# Altium schematic sheet PowerInput.SchDoc
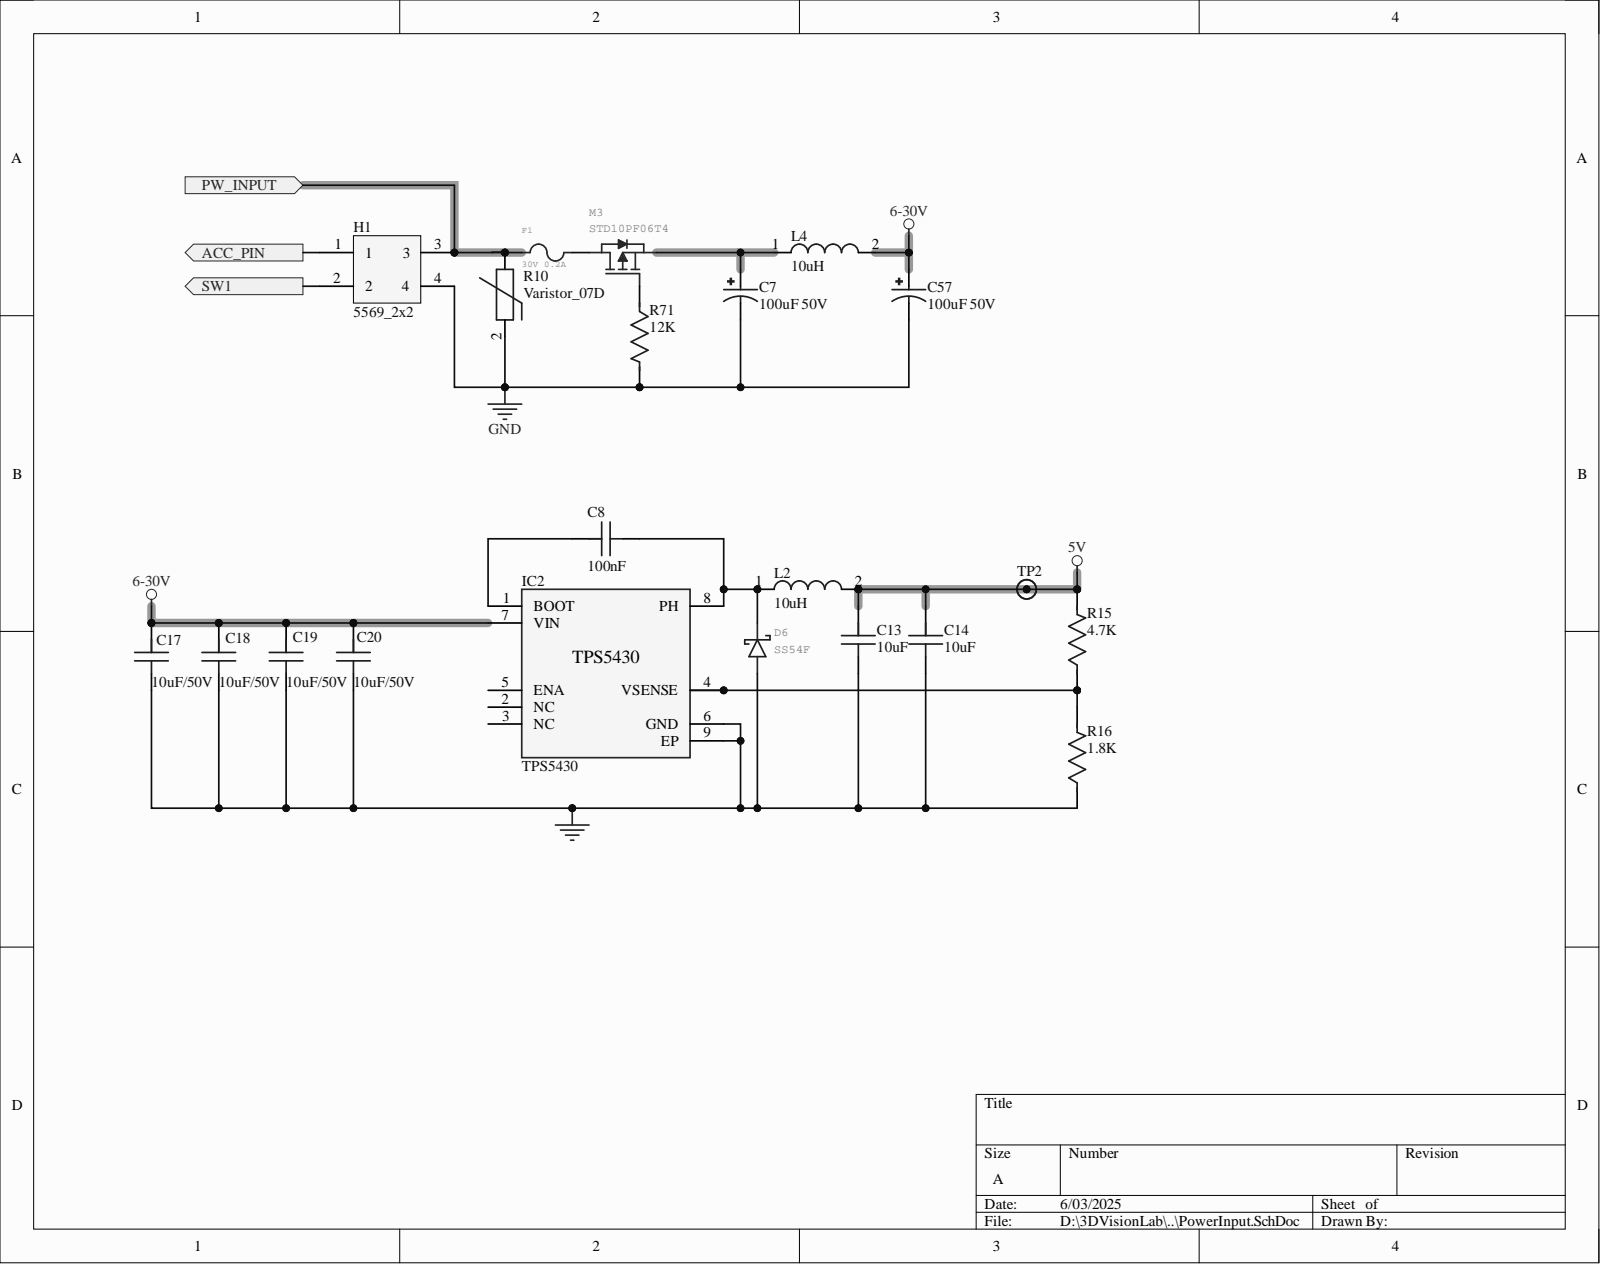
[diagram p0: PowerInput.SchDoc]

## Parts
C7  100uF 50V  [CAP_AL_8x12.5]
C8  100nF  [C0603]
C13  10uF  [C0805]
C14  10uF  [C0805]
C17  10uF/50V  [C0805]
C18  10uF/50V  [C0805]
C19  10uF/50V  [C0805]
C20  10uF/50V  [C0805]
C57  100uF 50V  [CAP_AL_8x12.5]
D6  SS54F  [SMAF]
F1  30V 0.2A  [FUSE_MF-MSMF150]
H1  5569_2x2  [5569_2x2]
IC2  TPS5430  [SOIC-8E]
L2  10uH  [L0630]
L4  10uH  [L0630]
M3  STD10PF06T4  [TO252-3]
R10  Varistor_07D  [Varistor_07D]
R15  4.7K  [R0603]
R16  1.8K  [R0603]
R71  12K  [R0603]
TP2  TestPoint_1MM  [TestPoint_1MM]  (value not drawn)

## Ports
ACC_PIN, PW_INPUT, SW1

## Nets
5V: C13.1 C14.1 L2.2 R15.2 TP2.1
6-30V: C17.1 C18.1 C19.1 C20.1 C57.1 IC2.7(VIN) L4.2
GND: C7.2 C13.2 C14.2 C17.2 C18.2 C19.2 C20.2 C57.2 D6.A H1.4 IC2.6(GND) IC2.9(EP) R10.2 R16.1 R71.2
ACC_PIN: H1.1 ; port ACC_PIN
PW_INPUT: F1.1 H1.3 R10.1 ; port PW_INPUT
SW1: H1.2 ; port SW1
NetC7_1: C7.1 L4.1 M3.2
NetC8_1: C8.1 IC2.1(BOOT)
NetC8_2: C8.2 D6.C IC2.8(PH) L2.1
NetF1_2: F1.2 M3.3
NetIC2_2: IC2.2(NC)
NetIC2_3: IC2.3(NC)
NetIC2_4: IC2.4(VSENSE) R15.1 R16.2
NetIC2_5: IC2.5(ENA)
NetM3_1: M3.1 R71.1

## Library data of the parts
C7 C57 = CAP_AL_8x12.5: Aluminum Electrolytic Capacitors - Radial Leaded 50VDC 1200uF 10000H 16x25mm; MF: Panasonic; MP: EEU-FS1H122; Availability: In Stock; Check_prices: https://www.snapeda.com/parts/EEU-FS1H122/Panasonic/view-part/?ref=eda; Component Kind: Standard; Package: RADIAL-2 Panasonic; Price: None; Purchase-URL: https://pricing.snapeda.com/search/part/EEU-FS1H122/?ref=eda; SnapEDA_Link: https://www.snapeda.com/parts/EEU-FS1H122/Panasonic/view-part/?ref=snap; Library Name: UY_Schlib.SchLib
C8 = Capacitor: Component Kind: Standard; Library Name: UY_Schlib.SchLib
C13 C14 C17-C20 = Capacitor 0805: Component Kind: Standard; Library Name: UY_Schlib.SchLib
D6 = SS54F: GateName_1: G$1; SymbolName_1: DIODE_S; Component Kind: Standard; DeviceSetName: SS54F; LibraryName: Uy_SMD; Package: SMAF; Library Name: UY_Schlib.SchLib
F1 = FUSE_MF-MSMF150: GateName_1: G$1; MF: Bourns; AVAILABILITY: Unavailable; Component Kind: Standard; DeviceSetName: MF-MSMF150; LibraryName: Uy_SMD; MP: MF-MSMF150; Package: FUSER_MF-MSMF150; PRICE: None; SymbolName_1: MF-MSMF150; Library Name: UY_Schlib.SchLib
IC2 = TPS5430: Buck Step-Down Converter  (5.5V to 36V Input, 3A); MF: Texas Instruments; MP: TPS5430DDA; Availability: Unavailable; Component Kind: Standard; DigiKey-Purchase-URL: https://snapeda.com/shop?store=DigiKey&id=226174; Mouser-Purchase-URL: https://snapeda.com/shop?store=Mouser&id=226174; Package: HSOIC-8 Texas Instruments; Price: None; SnapEDA_Link: https://www.snapeda.com/parts/TPS5430DDA/Texas%20Instruments/view-part/226174/?ref=snap; Texas_Instruments-Purchase-URL: https://snapeda.com/shop?store=Texas+Instruments&id=226174; Library Name: UY_Schlib.SchLib
L2 L4 = Inductor 0630: HIGH POWER INDUCTOR 1040; MF: Wurth Electronics; MP: 74437368047; Availability: Unavailable; Component Kind: Standard; Package: Nonstandard Wurth Electronics; Price: None; SnapEDA_Link: https://www.snapeda.com/parts/74437368047/Wurth%20Electronics%20Inc./view-part/286863/?ref=snap; Library Name: UY_Schlib.SchLib
M3 = MOSFETP_TO252: SOT-23; DeviceSetName: MOSFETN; GateName_1: G$1; SymbolName_1: MOSFET_N; Component Kind: Standard; LibraryName: Uy_SMD; Package: SOT23(1); Library Name: UY_Schlib.SchLib
R15 R16 R71 = Resistor 0603: SMD Resistor 0603; Manufacturer: Yageo; Manufacturer Part Number: RC0603FR-071KL; Max Power Dissipation: 100mW; Resistance Tolerance: 1%; Temperature Coefficient: 100ppm/°C; Value: 1kR; Voltage Rating: 50V; Case Code (Imperial): 0603; Case Code (Metric): 1608; Case/Package: 0603; Category: Chip SMD Resistors; Component Kind: Standard; Construction: Rectangular; Contact Plating: Tin,Matte; Depth: 0.8mm; Features: Moisture Resistant; Height: 0.45mm; Lead Free: 5697LeadFree; Length: 1.6mm; Max Operating Temperature: 155°C; Military Standard: Not; Min Operating Temperature: -55°C; Number of Terminations: 2; Packaging: Tape and Reel; Power Rating: 100mW; Pricing: 1=0.1, 10=0.018, 50=0.0106, 100=0.0088, 500=0.00592, 1000=0.00505 (USD); Reach SVHC Compliant: No; Resistor Type: Thick Film; RoHS: Yes; RoHS Compliant: Yes; Series: RC; Stock: 18662657; Supplier: Digi-Key; Supplier Part Number: 311-1.00KHRDKR-ND; Technology: THICK FILM; Termination: SMD/SMT; Tolerance: 1%; Library Name: UY_Schlib.SchLib
H1 = 5569_2x2: Component Kind: Standard; Library Name: UY_5569_Header.SchLib
R10 = Varistor_07D: Component Kind: Standard; Library Name: UY_Schlib.SchLib
TP2 = TestPoint_1MM: Component Kind: Standard; Library Name: UY_Schlib.SchLib
